# Altium schematic sheet SCH.SchDoc(SCH)
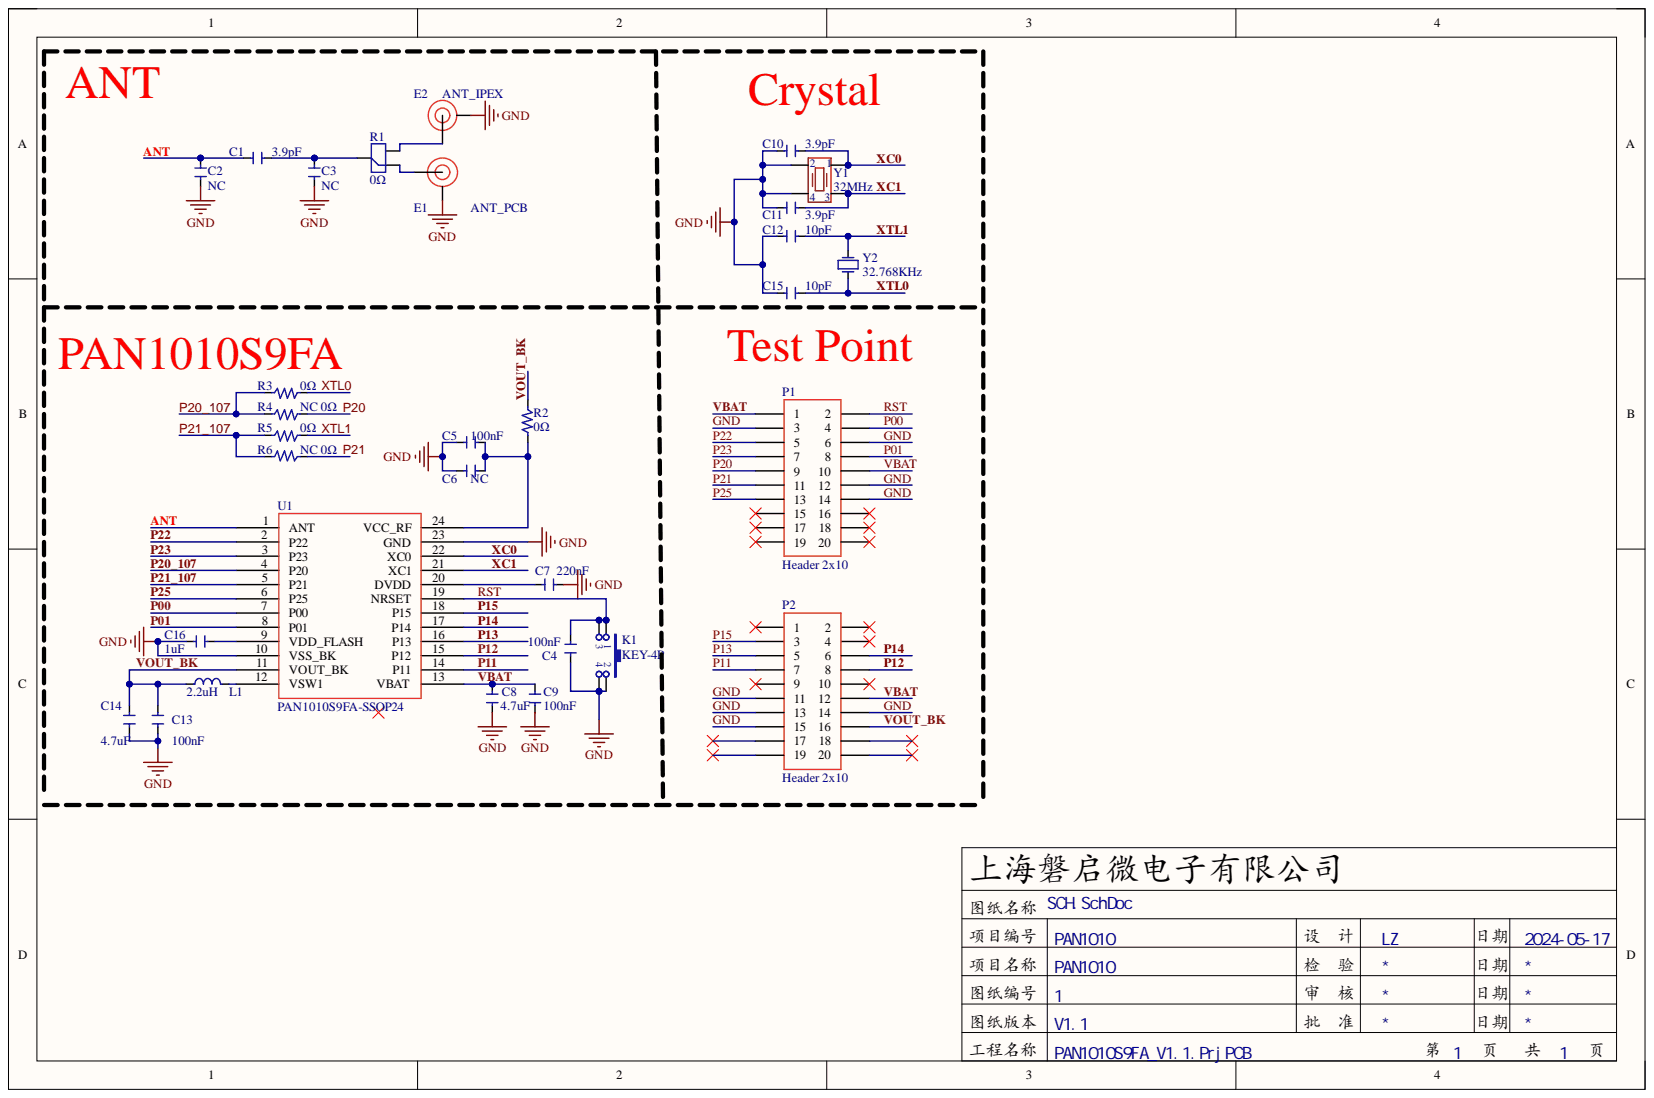
[diagram p0: SCH.SchDoc]

## Parts
C1  3.9pF  [0402_R_RF]
C2  NC  [0402_C_RF]
C3  NC  [0402_C_RF]
C4  100nF  [0402C_L]
C5  100nF  [0402C_L]
C6  NC  [0402C_L]
C7  220nF  [0402C_L]
C8  4.7uF  [0402C_L]
C9  100nF  [0402C_L]
C10  3.9pF  [0402C_L]
C11  3.9pF  [0402C_L]
C12  10pF  [0402C_L]
C13  100nF  [0402C_L]
C14  4.7uF  [0402C_L]
C15  10pF  [0402C_L]
C16  1uF  [0402C_L]
E1  ANT_PCB  [ANT_MIFA_CYPRESS]
E2  ANT_IPEX  [ANT IPEX-2]
K1  KEY-4P  [SW-SMD(4.2X3.25X2.5)]
L1  2.2uH  [SMD-2520-1.2]
P1  Header 2x10  [HEADER 2X10]
P2  Header 2x10  [HEADER 2X10]
R1  0Ω  [0402R-3P_L]
R2  0Ω  [0402R_L]
R3  0Ω  [0402R_L]
R4  NC 0Ω  [0402R_L]
R5  0Ω  [0402R_L]
R6  NC 0Ω  [0402R_L]
U1  PAN1010S9FA-SSOP24  [SSOP24(150x340 P25)]
Y1  32MHz  [SMD-2016-4P]
Y2  32.768KHz  [SMD-3215_2P FC - 135]

## Nets
ANT: C1.1 C2.2 U1.1(ANT)
GND: C2.1 C3.1 C4.2 C5.1 C6.1 C7.2 C8.1 C9.1 C10.1 C11.1 C12.2 C13.1 C14.1 C15.2 C16.1 E1.2 E2.2 K1.2 K1.4 P1.3 P1.6 P1.12 P1.14 P2.11 P2.13 P2.14 P2.15 U1.10(VSS_BK) U1.23(GND) Y1.2 Y1.4
P00: P1.4 U1.7(P00)
P01: P1.8 U1.8(P01)
P11: P2.7 U1.14(P11)
P12: P2.8 U1.15(P12)
P13: P2.5 U1.16(P13)
P14: P2.6 U1.17(P14)
P15: P2.3 U1.18(P15)
P20: P1.9 R4.1
P20_107: R3.2 R4.2 U1.4(P20)
P21: P1.11 R6.2
P21_107: R5.2 R6.1 U1.5(P21)
P22: P1.5 U1.2(P22)
P23: P1.7 U1.3(P23)
P25: P1.13 U1.6(P25)
RST: C4.1 K1.1 K1.3 P1.2 U1.19(NRSET)
VBAT: C8.2 C9.2 P1.1 P1.10 P2.12 U1.13(VBAT)
VOUT_BK: C13.2 C14.2 L1.1 P2.16 R2.2 U1.11(VOUT_BK)
XC0: C10.2 U1.22(XC0) Y1.1
XC1: C11.2 U1.21(XC1) Y1.3
XTL0: C15.1 R3.1 Y2.2
XTL1: C12.1 R5.1 Y2.1
NetC1_2: C1.2 C3.2 R1.1
NetC5_2: C5.2 C6.2 R2.1 U1.24(VCC_RF)
NetC7_1: C7.1 U1.20(DVDD)
NetC16_2: C16.2 U1.9(VDD_FLASH)
NetE1_1: E1.1 R1.2
NetE2_1: E2.1 R1.3
NetL1_2: L1.2 U1.12(VSW1)

Not connected: P1 15 16 17 18 19 20; P2 1 2 4 9 10 17 18 19 20

## Library data of the parts
C1-C16 = CAP_Ceramic: 贴片陶瓷电容; Component Kind: Standard; Library Name: Panchip Library.IntLib
E1 = ANT_SMA: 180度横插式 3Pin SMA; Component Kind: Standard; Library Name: Panchip Library.IntLib
K1 = KEY-4P: 贴片4脚触摸按键; Component Kind: Standard; Library Name: SWITCH-KEY.SchLib
L1 = Inductor_Power: 贴片功率电感; 厂商: Sunltech(韩国顺磁); 型号: SLM25202R2MIT; Component Kind: Standard; 立创编号: C216158; Library Name: Panchip Library.IntLib
P1 P2 = Header 2x10: Header 2x10,2.54mm; Component Kind: Standard; Library Name: Panchip Library.IntLib
R1 = Resistor-3P: 贴片电阻; Component Kind: Standard; Library Name: Panchip Library.IntLib
R2-R6 = Resistor1: 贴片电阻; Component Kind: Standard; Library Name: Panchip Library.IntLib
U1 = PAN1010-SSOP24: PAN1010 蓝牙芯片; Component Kind: Standard; Library Name: MCU.SchLib
Y1 = Crystal 4pin: 贴片4脚晶振; 品牌: EPSON(爱普生); 型号: X1E000021016300; Component Kind: Standard; Library Name: Panchip Library.IntLib
Y2 = Crystal 2pin: 贴片2脚晶振; Component Kind: Standard; Library Name: Panchip Library.IntLib
E2 = ANT_IPEX: Component Kind: Standard; Description: ANT_IPEX; Library Name: Panchip Library.IntLib
